# Altium schematic sheet CPU.SchDoc(CPU)
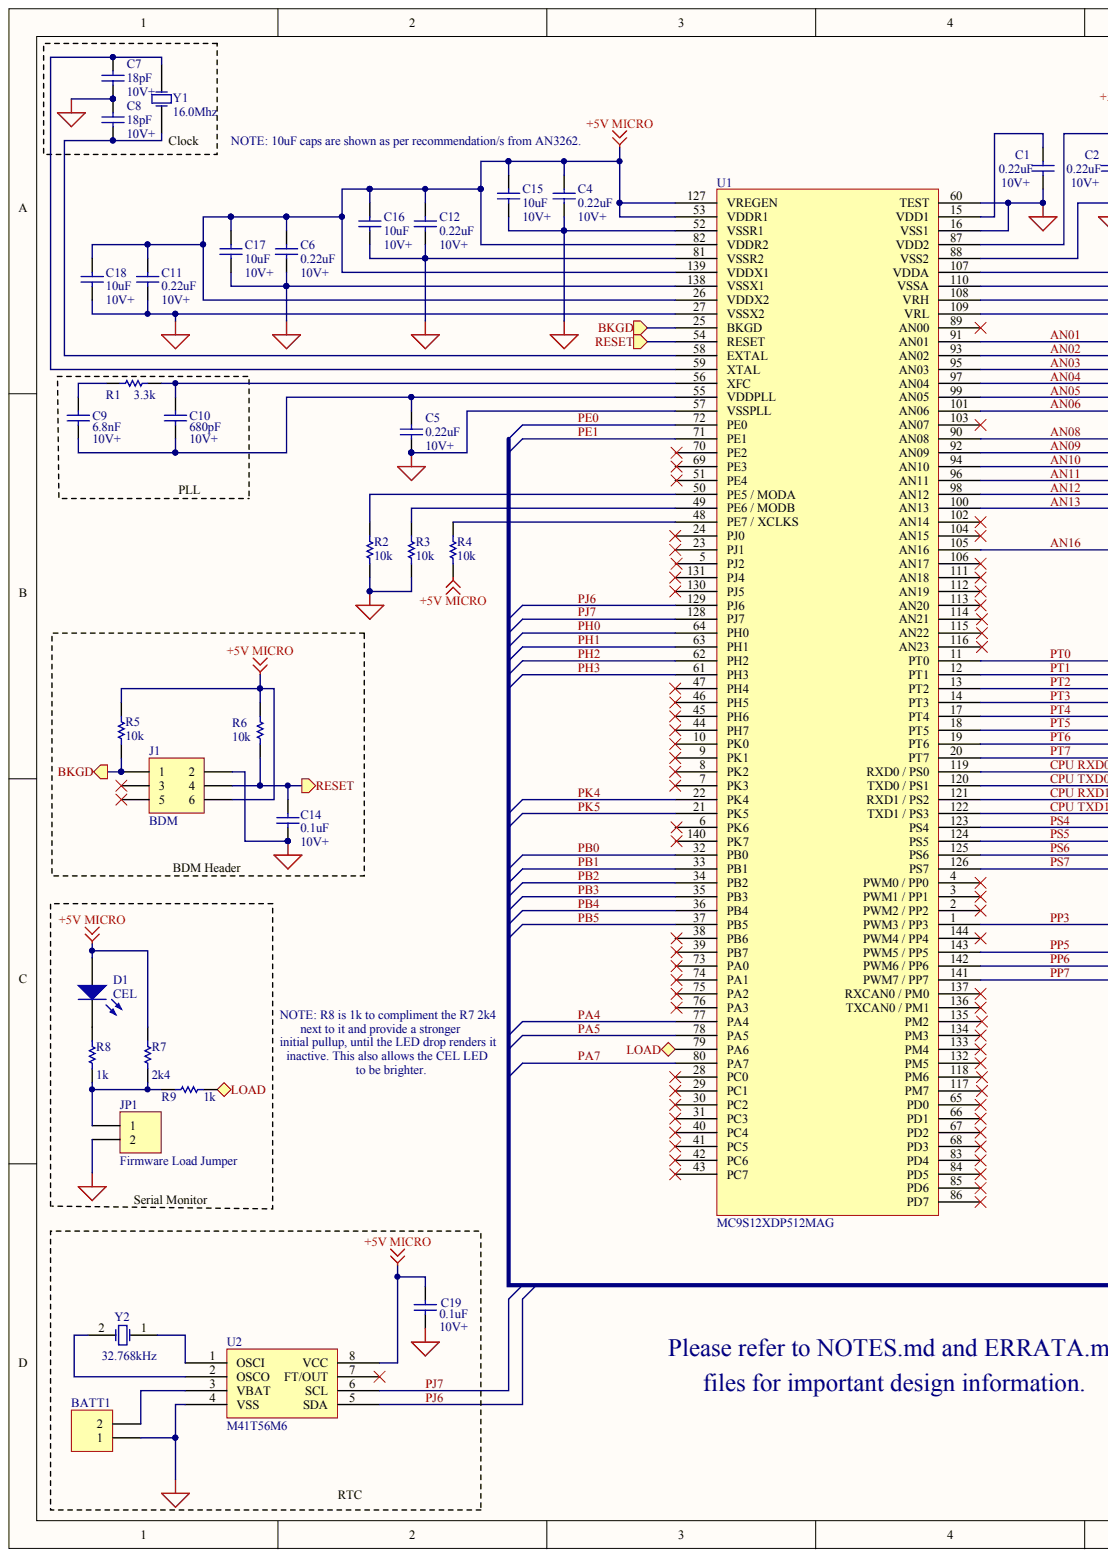
[diagram p0: CPU.SchDoc, left]
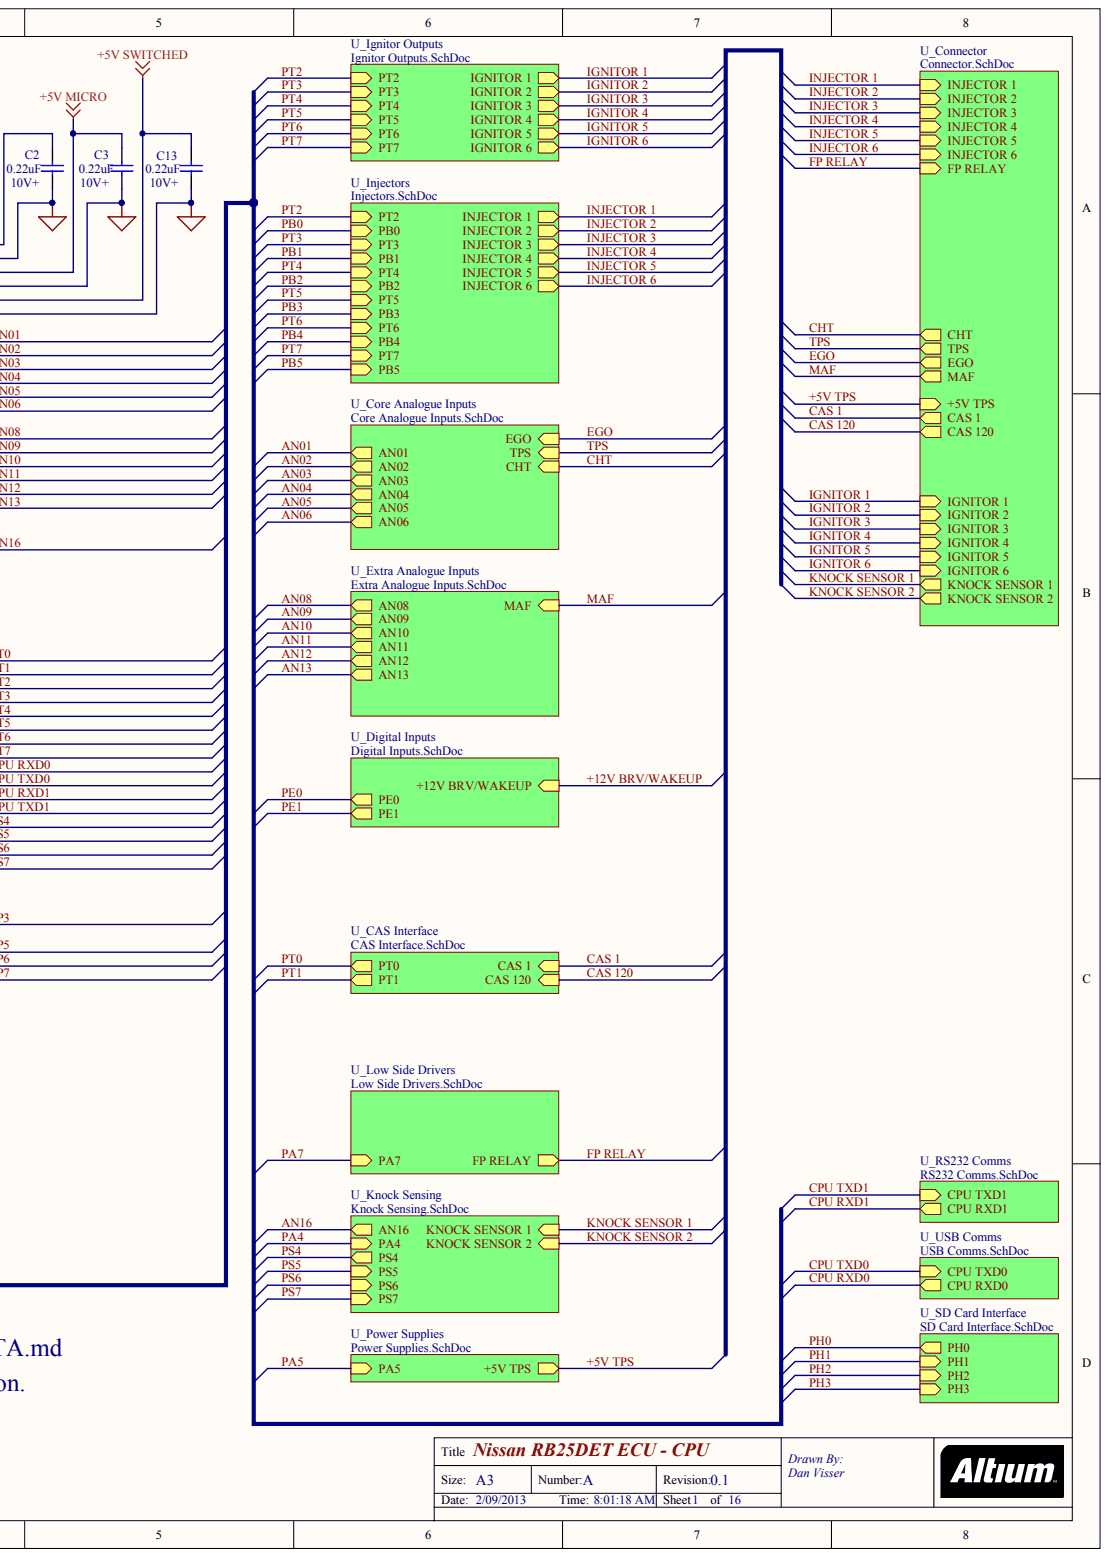
[diagram p1: CPU.SchDoc, right]

## Parts
BATT1  (no value)
C1  value 0.22uF
C2  value 0.22uF
C3  value 0.22uF
C4  value 0.22uF
C5  value 0.22uF
C6  value 0.22uF
C7  value 18pF
C8  value 18pF
C9  value 6.8nF
C10  value 680pF
C11  value 0.22uF
C12  value 0.22uF
C13  value 0.22uF
C14  value 0.1uF
C15  value 10uF
C16  value 10uF
C17  value 10uF
C18  value 10uF
C19  value 0.1uF
D1  CEL
J1  BDM
JP1  Firmware Load Jumper
R1  value 3.3k
R2  value 10k
R3  value 10k
R4  value 10k
R5  value 10k
R6  value 10k
R7  value 2k4
R8  value 1k
R9  value 1k
U1  MC9S12XDP512MAG
U2  M41T56M6
Y1  16.0Mhz
Y2  32.768kHz

## Ports
BKGD, LOAD, RESET

## Nets
+5V MICRO: C3.2 C4.2 C6.2 C11.2 C12.2 C15.2 C16.2 C17.2 C18.2 C19.1 D1.1 J1.6 R4.1 R5.2 R6.2 R7.2 U1.26(VDDX2) U1.53(VDDR1) U1.82(VDDR2) U1.107(VDDA) U1.127(VREGEN) U1.139(VDDX1) U2.8(VCC)
+5V SWITCHED: C13.2 U1.108(VRH)
: BATT1.1 C1.1 C2.1 C3.1 C4.1 C5.2 C6.1 C7.1 C8.2 C11.1 C12.1 C13.1 C14.2 C15.1 C16.1 C17.1 C18.1 C19.2 J1.2 JP1.2 R2.1 R3.1 U1.16(VSS1) U1.27(VSSX2) U1.52(VSSR1) U1.57(VSSPLL) U1.60(TEST) U1.81(VSSR2) U1.88(VSS2) U1.109(VRL) U1.110(VSSA) U1.138(VSSX1) U2.4(VSS)
AN01: U1.91(AN01)
AN02: U1.93(AN02)
AN03: U1.95(AN03)
AN04: U1.97(AN04)
AN05: U1.99(AN05)
AN06: U1.101(AN06)
AN08: U1.90(AN08)
AN09: U1.92(AN09)
AN10: U1.94(AN10)
AN11: U1.96(AN11)
AN12: U1.98(AN12)
AN13: U1.100(AN13)
AN16: U1.105(AN16)
BKGD: J1.1 R5.1 U1.25(BKGD) ; port BKGD
CPU RXD0: U1.119(RXD0_/_PS0)
CPU RXD1: U1.121(RXD1_/_PS2)
CPU TXD0: U1.120(TXD0_/_PS1)
CPU TXD1: U1.122(TXD1_/_PS3)
LOAD: R9.2 U1.79(PA6) ; port LOAD
PA4: U1.77(PA4)
PA5: U1.78(PA5)
PA7: U1.80(PA7)
PB0: U1.32(PB0)
PB1: U1.33(PB1)
PB2: U1.34(PB2)
PB3: U1.35(PB3)
PB4: U1.36(PB4)
PB5: U1.37(PB5)
PE0: U1.72(PE0)
PE1: U1.71(PE1)
PH0: U1.64(PH0)
PH1: U1.63(PH1)
PH2: U1.62(PH2)
PH3: U1.61(PH3)
PJ6: U1.129(PJ6) U2.5(SDA)
PJ7: U1.128(PJ7) U2.6(SCL)
PK4: U1.22(PK4)
PK5: U1.21(PK5)
PP3: U1.1(PWM3_/_PP3)
PP5: U1.143(PWM5_/_PP5)
PP6: U1.142(PWM6_/_PP6)
PP7: U1.141(PWM7_/_PP7)
PS4: U1.123(PS4)
PS5: U1.124(PS5)
PS6: U1.125(PS6)
PS7: U1.126(PS7)
PT0: U1.11(PT0)
PT1: U1.12(PT1)
PT2: U1.13(PT2)
PT3: U1.14(PT3)
PT4: U1.17(PT4)
PT5: U1.18(PT5)
PT6: U1.19(PT6)
PT7: U1.20(PT7)
RESET: C14.1 J1.4 R6.1 U1.54(RESET) ; port RESET
NetBATT1_2: BATT1.2 U2.3(VBAT)
NetC1_2: C1.2 U1.15(VDD1)
NetC2_2: C2.2 U1.87(VDD2)
NetC5_1: C5.1 C9.1 C10.1 U1.55(VDDPLL)
NetC7_2: C7.2 U1.59(XTAL) Y1.2
NetC8_1: C8.1 U1.58(EXTAL) Y1.1
NetC9_2: C9.2 R1.1
NetC10_2: C10.2 R1.2 U1.56(XFC)
NetD1_2: D1.2 R8.2
NetJ1_3: J1.3
NetJ1_5: J1.5
NetJP1_1: JP1.1 R7.1 R8.1 R9.1
NetR2_2: R2.2 U1.50(PE5_/_MODA)
NetR3_2: R3.2 U1.49(PE6_/_MODB)
NetR4_2: R4.2 U1.48(PE7_/_XCLKS)
NetU1_2: U1.2(PWM2_/_PP2)
NetU1_3: U1.3(PWM1_/_PP1)
NetU1_4: U1.4(PWM0_/_PP0)
NetU1_5: U1.5(PJ2)
NetU1_6: U1.6(PK6)
NetU1_7: U1.7(PK3)
NetU1_8: U1.8(PK2)
NetU1_9: U1.9(PK1)
NetU1_10: U1.10(PK0)
NetU1_23: U1.23(PJ1)
NetU1_24: U1.24(PJ0)
NetU1_28: U1.28(PC0)
NetU1_29: U1.29(PC1)
NetU1_30: U1.30(PC2)
NetU1_31: U1.31(PC3)
NetU1_38: U1.38(PB6)
NetU1_39: U1.39(PB7)
NetU1_40: U1.40(PC4)
NetU1_41: U1.41(PC5)
NetU1_42: U1.42(PC6)
NetU1_43: U1.43(PC7)
NetU1_44: U1.44(PH7)
NetU1_45: U1.45(PH6)
NetU1_46: U1.46(PH5)
NetU1_47: U1.47(PH4)
NetU1_51: U1.51(PE4)
NetU1_65: U1.65(PD0)
NetU1_66: U1.66(PD1)
NetU1_67: U1.67(PD2)
NetU1_68: U1.68(PD3)
NetU1_69: U1.69(PE3)
NetU1_70: U1.70(PE2)
NetU1_73: U1.73(PA0)
NetU1_74: U1.74(PA1)
NetU1_75: U1.75(PA2)
NetU1_76: U1.76(PA3)
NetU1_83: U1.83(PD4)
NetU1_84: U1.84(PD5)
NetU1_85: U1.85(PD6)
NetU1_86: U1.86(PD7)
NetU1_89: U1.89(AN00)
NetU1_102: U1.102(AN14)
NetU1_103: U1.103(AN07)
NetU1_104: U1.104(AN15)
NetU1_106: U1.106(AN17)
NetU1_111: U1.111(AN18)
NetU1_112: U1.112(AN19)
NetU1_113: U1.113(AN20)
NetU1_114: U1.114(AN21)
NetU1_115: U1.115(AN22)
NetU1_116: U1.116(AN23)
NetU1_117: U1.117(PM7)
NetU1_118: U1.118(PM6)
NetU1_130: U1.130(PJ5)
NetU1_131: U1.131(PJ4)
NetU1_132: U1.132(PM5)
NetU1_133: U1.133(PM4)
NetU1_134: U1.134(PM3)
NetU1_135: U1.135(PM2)
NetU1_136: U1.136(TXCAN0_/_PM1)
NetU1_137: U1.137(RXCAN0_/_PM0)
NetU1_140: U1.140(PK7)
NetU1_144: U1.144(PWM4_/_PP4)
NetU2_1: U2.1(OSCI) Y2.1
NetU2_2: U2.2(OSCO) Y2.2
NetU2_7: U2.7(FT/OUT)
+5V TPS:
+12V BRV/WAKEUP:
CAS 1:
CAS 120:
CHT:
EGO:
FP RELAY:
IGNITOR 1:
IGNITOR 2:
IGNITOR 3:
IGNITOR 4:
IGNITOR 5:
IGNITOR 6:
INJECTOR 1:
INJECTOR 2:
INJECTOR 3:
INJECTOR 4:
INJECTOR 5:
INJECTOR 6:
KNOCK SENSOR 1:
KNOCK SENSOR 2:
MAF:
N00229:
N00230:
TPS:

## Library data of the parts
BATT1 C1-C19 D1 J1 JP1 R1-R9 U1 U2 Y1 Y2 = (no symbol name)
  BATT1 J1 JP1: LatestRevisionDate: 17-Jul-2002; LatestRevisionNote: Re-released for DXP Platform.
  C1-C19: Published: 8-Jun-2000; LatestRevisionDate: 17-Jul-2002; LatestRevisionNote: Re-released for DXP Platform.; PackageReference: RAD-0.3; PackageDescription: Radial Cap, Thru-Hole; 2 Leads; 0.3 in Pin Spacing
  D1: Published: 8-Jun-2000; LatestRevisionDate: 08-Jul-2005; LatestRevisionNote: Mech Layer 1 removed.; PackageReference: LED-0; PackageDescription: LED; 2 Leads
  R1-R9: Published: 8-Jun-2000; LatestRevisionDate: 17-Jul-2002; LatestRevisionNote: Re-released for DXP Platform.; PackageReference: AXIAL-0.3; PackageDescription: Axial Device, Thru-Hole; 2 Leads; 0.3 in Pin Spacing
  Y1 Y2: Published: 8-Jun-2000; LatestRevisionDate: 17-Jul-2002; LatestRevisionNote: Re-released for DXP Platform.; PackageReference: R38; PackageDescription: Crystal, Thru-Hole; 2 Leads; Body 3.1 x 8.2 mm (Dia.xH)
  BATT1 C1-C19 D1 J1 JP1 R1-R9 Y1 Y2: Publisher: Altium Limited
